# Altium schematic sheet XoomFloppy Pro.SchDoc("XoomFloppy Pro")
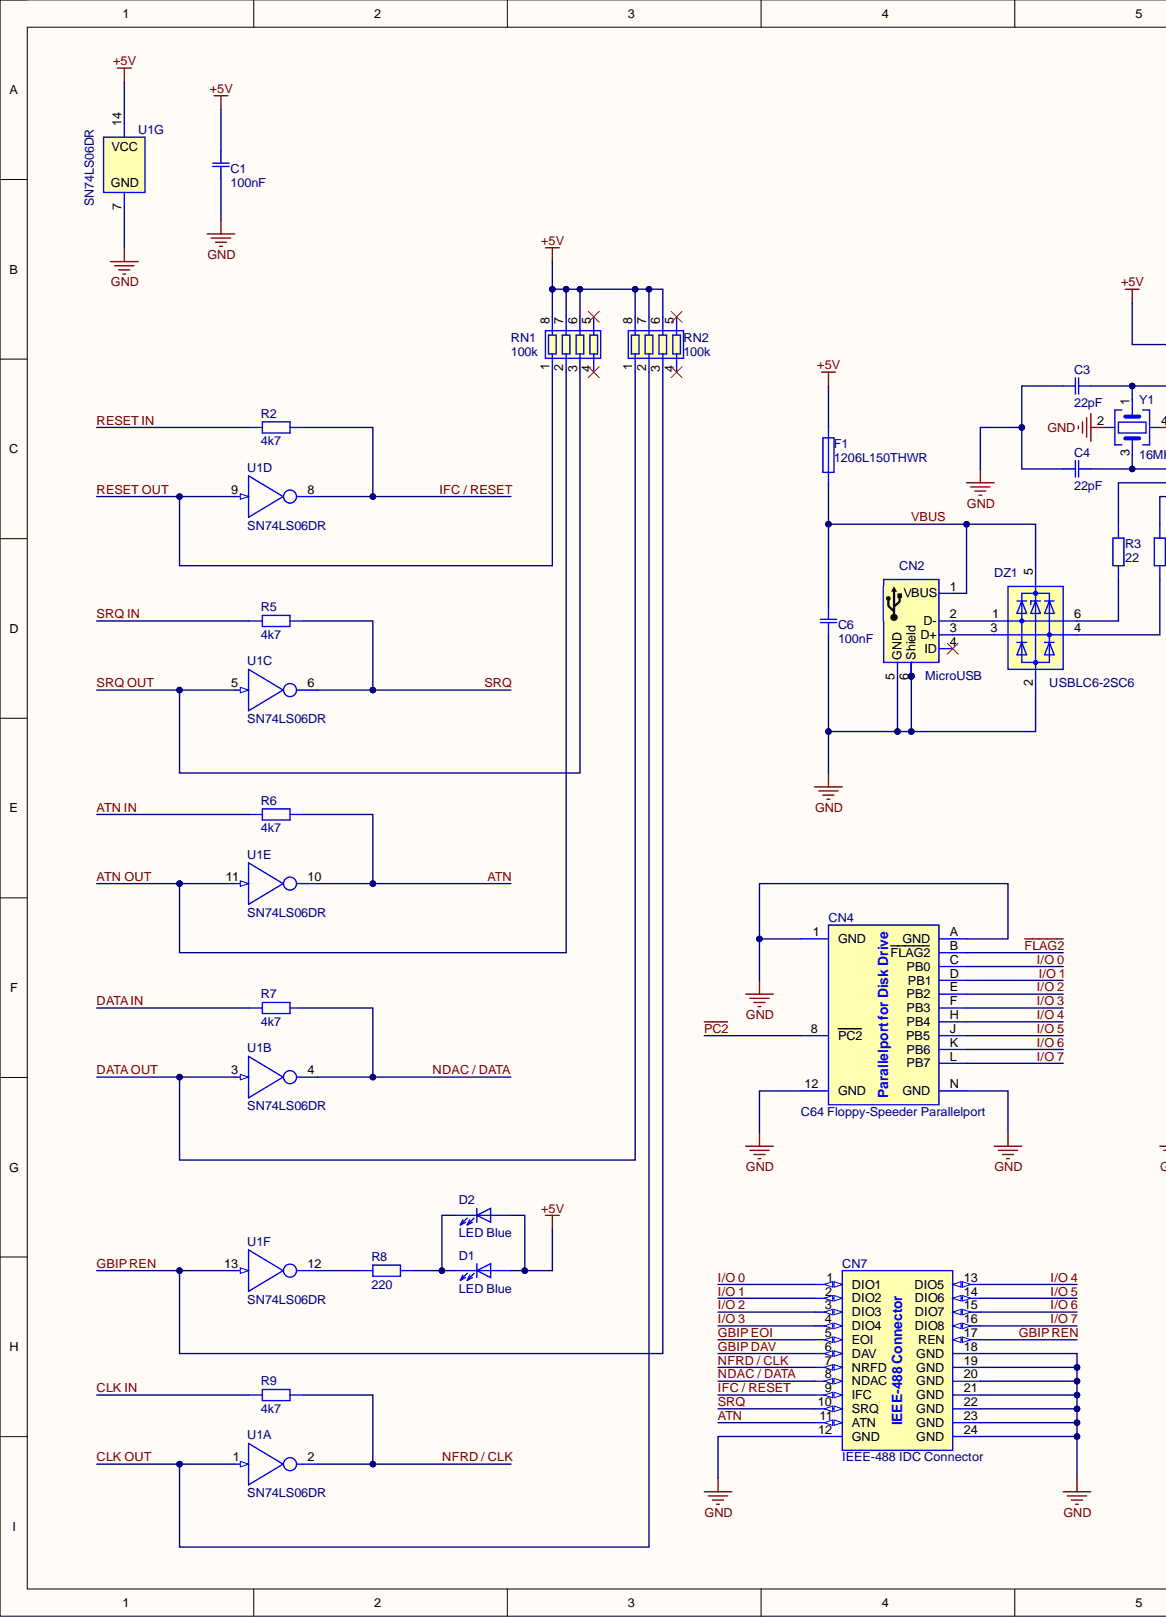
[diagram p0: XoomFloppy Pro.SchDoc, left]
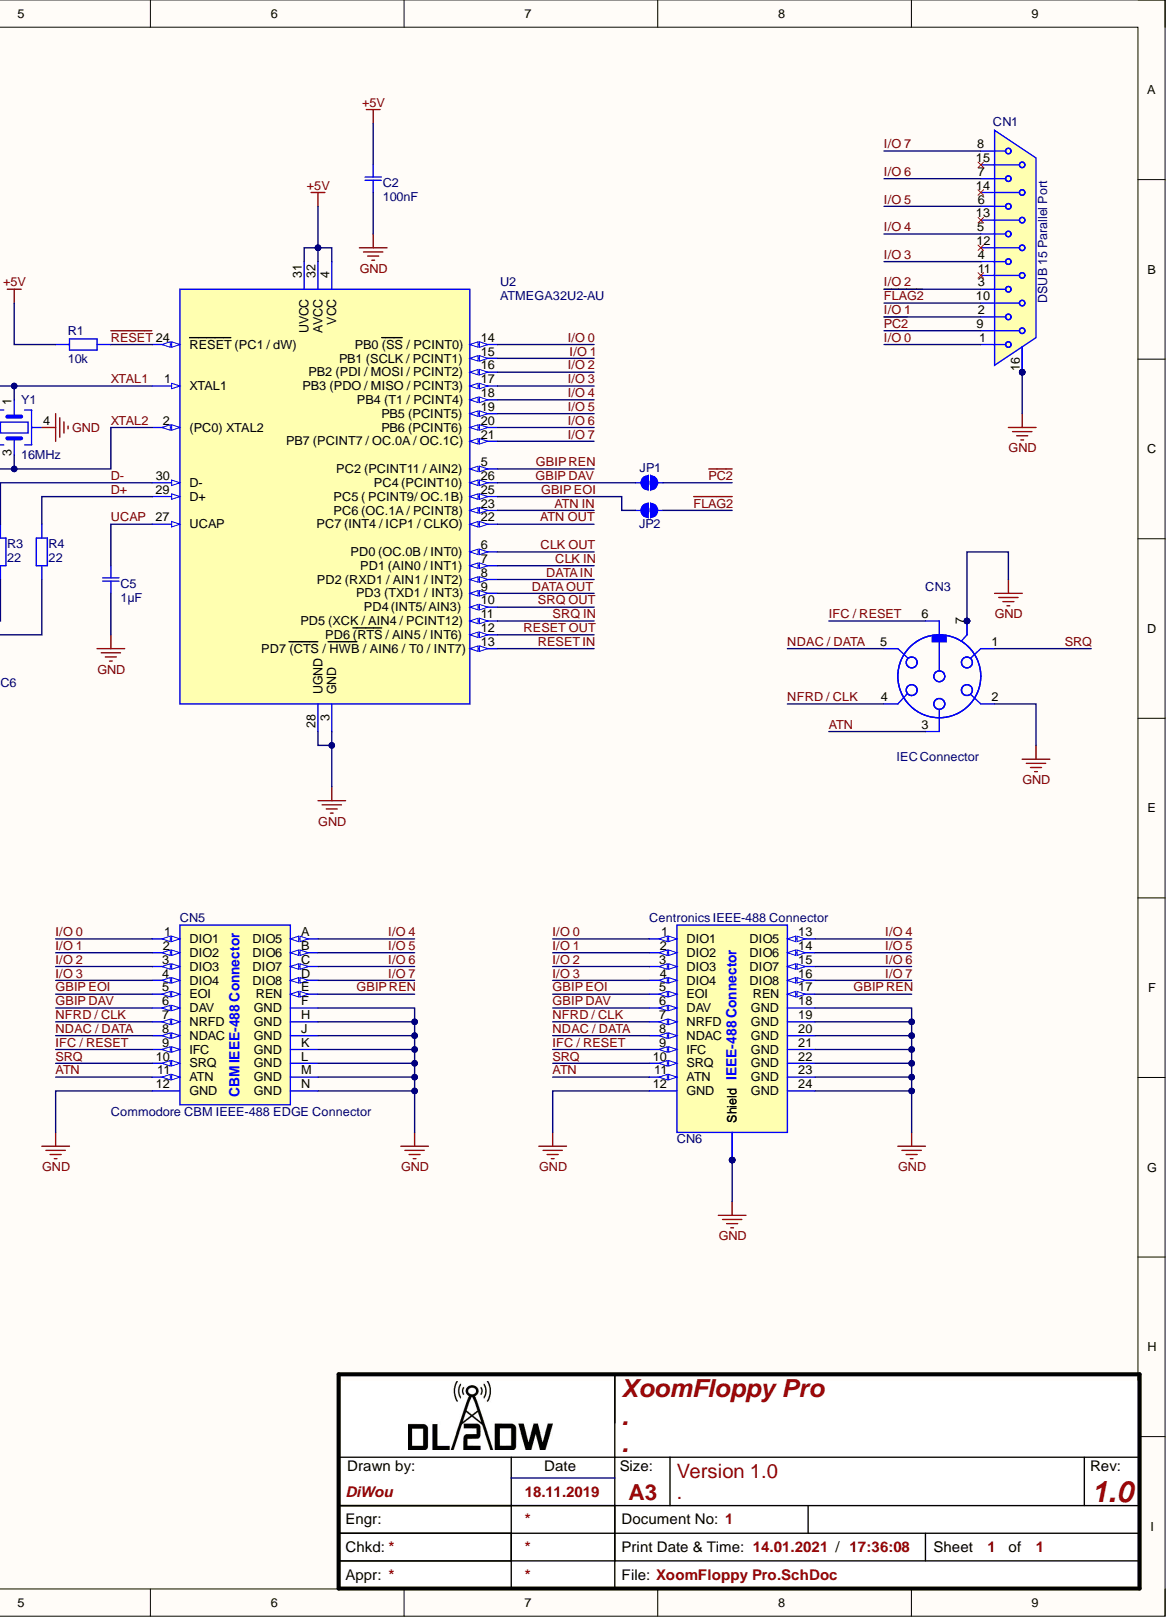
[diagram p1: XoomFloppy Pro.SchDoc, right]

## Parts
C1  100nF  [CAP 100nF SMD 0603 C0603C104J3RACTU]
C2  100nF  [CAP 100nF SMD 0603 C0603C104J3RACTU]
C3  22pF  [CAP 22pF SMD 0603 C0603C220J5RAC7867]
C4  22pF  [CAP 22pF SMD 0603 C0603C220J5RAC7867]
C5  1µF  [CAP 1µF SMD 0603 C0603C105K3RACTU]
C6  100nF  [CAP 100nF SMD 0603 C0603C104J3RACTU]
CN1  DSUB 15 Parallel Port  [D-SUB 15 Male Connector Right Angle 8.1mm]
CN2  MicroUSB  [MicroUSB Connector Right Angle Receptacle (Würth 629105150521)]
CN3  IEC Connector  [DIN 45322 Circular Connector (DIN-6)]
CN4  C64 Floppy-Speeder Parallelport  [C64 User Port]
CN5  Commodore CBM IEEE-488 EDGE Connector  [CBM IEEE-488 EDGE Connector]
CN6  Centronics IEEE-488 Connector  [GPIB Centronics Female 2x12pos. Connector]
CN7  IEEE-488 IDC Connector  [IEEE-488 IDC Header 2x12 P2.54mm Straight]
D1  LED Blue  Color: Blue  [LED Blue SMD 0603]
D2  LED Blue  Color: Blue  [LED 3mm Blue Straight]
DZ1  USBLC6-2SC6  [USBLC6-2SC6]
F1  1206L150THWR  [Littelfuse 1206L150THWR]
JP1  Solder Jumper Open  [Solder Jumper Open Variant 2]  (value not drawn)  (jumper)
JP2  Solder Jumper Open  [Solder Jumper Open Variant 2]  (value not drawn)  (jumper)
R1  10k  [10k Ohm R 0603 SMD]
R2  4k7  [4,7k Ohm R 0603 SMD]
R3  22  [22 Ohm R 0603 SMD]
R4  22  [22 Ohm R 0603 SMD]
R5  4k7  [4,7k Ohm R 0603 SMD]
R6  4k7  [4,7k Ohm R 0603 SMD]
R7  4k7  [4,7k Ohm R 0603 SMD]
R8  220  [220 Ohm R 0603 SMD]
R9  4k7  [4,7k Ohm R 0603 SMD]
RN1  100k  [RES ARRAY 4 RES 100K OHM 0804]
RN2  100k  [RES ARRAY 4 RES 100K OHM 0804]
U1  SN74LS06DR  [SN74LS06DR SOIC-14 P1.27mm W3.9mm]  (parts A,B,C,D,E,F,G)
U2  ATMEGA32U2-AU  [TQFP-32 P0.8mm 7x7mm ATMega32u2]
Y1  16MHz  [Crystal 16MHz SMD 2.0x1.6mm 4pos GND2-4]

## Nets
+5V: C1.2 C2.2 D1.2 D2.2 F1.2 R1.1 RN1.6 RN1.7 RN1.8 RN2.6 RN2.7 RN2.8 U1.14 U2.4(VCC) U2.31(UVCC) U2.32(AVCC)
GND: C1.1 C2.1 C3.1 C4.1 C5.1 C6.1 CN1.16 CN1.17 CN2.5(GND) CN2.6(Shield) CN2.7 CN2.8 CN2.9 CN3.2 CN3.7 CN3.8 CN4.1(GND) CN4.12(GND) CN4.A(GND) CN4.N(GND) CN5.12(GND) CN5.F(GND) CN5.H(GND) CN5.J(GND) CN5.K(GND) CN5.L(GND) CN5.M(GND) CN5.N(GND) CN6.12(GND) CN6.18(GND) CN6.19(GND) CN6.20(GND) CN6.21(GND) CN6.22(GND) CN6.23(GND) CN6.24(GND) CN6.Shield1(Shield) CN6.Shield2 CN7.12(GND) CN7.18(GND) CN7.19(GND) CN7.20(GND) CN7.21(GND) CN7.22(GND) CN7.23(GND) CN7.24(GND) DZ1.2 U1.7 U2.3(GND) U2.28(UGND) Y1.2 Y1.4
~{FLAG2}: CN1.10 CN4.B(FLAG2) JP2.2
~{PC2}: CN1.9 CN4.8(PC2) JP1.2
~{RESET}: R1.2 U2.24(RESET_(PC1_/_dW))
ATN: CN3.3 CN5.11(ATN) CN6.11(ATN) CN7.11(ATN) R6.2 U1.10
ATN IN: R6.1 U2.23(PC6_(OC.1A_/_PCINT8))
ATN OUT: RN1.2 U1.11 U2.22(PC7_(INT4_/_ICP1_/_CLKO))
CLK IN: R9.1 U2.7(PD1_(AIN0_/_INT1))
CLK OUT: RN2.2 U1.1 U2.6(PD0_(OC.0B_/_INT0))
D+: R4.2 U2.29(D+)
D-: R3.2 U2.30(D-)
DATA IN: R7.1 U2.8(PD2_(RXD1_/_AIN1_/_INT2))
DATA OUT: RN2.1 U1.3 U2.9(PD3_(TXD1_/_INT3))
GBIP DAV: CN5.6(DAV) CN6.6(DAV) CN7.6(DAV) JP1.1 U2.26(PC4_(PCINT10))
GBIP EOI: CN5.5(EOI) CN6.5(EOI) CN7.5(EOI) JP2.1 U2.25(PC5_(_PCINT9/_OC.1B))
GBIP REN: CN5.E(REN) CN6.17(REN) CN7.17(REN) RN2.3 U1.13 U2.5(PC2_(PCINT11_/_AIN2))
I/O 0: CN1.1 CN4.C(PB0) CN5.1(DIO1) CN6.1(DIO1) CN7.1(DIO1) U2.14(PB0_(SS_/_PCINT0))
I/O 1: CN1.2 CN4.D(PB1) CN5.2(DIO2) CN6.2(DIO2) CN7.2(DIO2) U2.15(PB1_(SCLK_/_PCINT1))
I/O 2: CN1.3 CN4.E(PB2) CN5.3(DIO3) CN6.3(DIO3) CN7.3(DIO3) U2.16(PB2_(PDI_/_MOSI_/_PCINT2))
I/O 3: CN1.4 CN4.F(PB3) CN5.4(DIO4) CN6.4(DIO4) CN7.4(DIO4) U2.17
I/O 4: CN1.5 CN4.H(PB4) CN5.A(DIO5) CN6.13(DIO5) CN7.13(DIO5) U2.18(PB4_(T1_/_PCINT4))
I/O 5: CN1.6 CN4.J(PB5) CN5.B(DIO6) CN6.14(DIO6) CN7.14(DIO6) U2.19(PB5_(PCINT5))
I/O 6: CN1.7 CN4.K(PB6) CN5.C(DIO7) CN6.15(DIO7) CN7.15(DIO7) U2.20(PB6_(PCINT6))
I/O 7: CN1.8 CN4.L(PB7) CN5.D(DIO8) CN6.16(DIO8) CN7.16(DIO8) U2.21(PB7_(PCINT7_/_OC.0A_/_OC.1C))
IFC / RESET: CN3.6 CN5.9(IFC) CN6.9(IFC) CN7.9(IFC) R2.2 U1.8
NDAC / DATA: CN3.5 CN5.8(NDAC) CN6.8(NDAC) CN7.8(NDAC) R7.2 U1.4
NFRD / CLK: CN3.4 CN5.7(NRFD) CN6.7(NRFD) CN7.7(NRFD) R9.2 U1.2
RESET IN: R2.1 U2.13(PD7_(CTS_/_HWB_/_AIN6_/_T0_/_INT7))
RESET OUT: RN1.1 U1.9 U2.12(PD6_(RTS_/_AIN5_/_INT6))
SRQ: CN3.1 CN5.10(SRQ) CN6.10(SRQ) CN7.10(SRQ) R5.2 U1.6
SRQ IN: R5.1 U2.11(PD5_(XCK_/_AIN4_/_PCINT12))
SRQ OUT: RN1.3 U1.5 U2.10(PD4_(INT5/_AIN3))
UCAP: C5.2 U2.27(UCAP)
VBUS: C6.2 CN2.1(VBUS) DZ1.5 F1.1
XTAL1: C3.2 U2.1(XTAL1) Y1.1
XTAL2: C4.2 U2.2((PC0)_XTAL2) Y1.3
NetCN2_2: CN2.2(D-) DZ1.1
NetCN2_3: CN2.3(D+) DZ1.3
NetD1_1: D1.1 D2.1 R8.2
NetDZ1_4: DZ1.4 R4.1
NetDZ1_6: DZ1.6 R3.1
NetR8_1: R8.1 U1.12

Not connected: CN1 11 12 13 14 15; CN2 4(ID); RN1 4 5; RN2 4 5

## Library data of the parts
C1 C2 C6 = CMP-Capacitors-Ceramic_Capacitors-00012-1: KEMET         C0603C104J3RACTU             SMD Multilayer Ceramic Capacitor, 0603 [1608 Metric], 0.1 F, 25 V,  5%, X7R, C Series; Voltage: 25V; Capacitance: 100nF; Case Code (Imperial): 0603; Case Code (Metric): 1608; Case/Package: 0603; Component Kind: Standard; Contact Plating: Tin,Matte; Depth: 0.8mm; Dielectric: X7R; Dielectric Material: Ceramic; Height: 0.8mm; Lead Pitch: 0.7mm; Length: 1.6mm; Library Name: PCB-Tech Cloud; Max Operating Temperature: 125°C; Min Operating Temperature: -55°C; Mount: Surface Mount,PCB; Number of Pins: 2; Package Quantity: 4000; Packaging: Tape and Reel; Radiation Hardening: No; REACH SVHC: No SVHC; Termination: SMD/SMT; Thickness: 0.034inch; Tolerance: 5%; Voltage Rating: 25V; Voltage Rating (DC): 25V; Width: 0.031inch
C3 C4 = CMP-Capacitors-Ceramic_Capacitors-00013-1: 22.0PF 50.0V; Capacitance: 2.2E-11F; Dielectric: X7R; Tolerance: 5%; Voltage Rating (DC): 50V; Case/Package: 0603; Component Kind: Standard; Dielectric Material: Ceramic; Library Name: PCB-Tech Cloud
C5 = CMP-Capacitors-Ceramic_Capacitors-00014-1: KEMET 1F Multilayer Ceramic Capacitor (MLCC) 25 V 10% X7R dielectric C SMD max op. temp. +125C; Capacitance: 1uF; Dissipation Factor: 5%; Voltage: 25V; Case Code (Imperial): 0603; Case Code (Metric): 1608; Case/Package: 0603; Component Kind: Standard; Depth: 0.8mm; Dielectric: X7R; Dielectric Material: Ceramic; Height: 0.8mm; Length: 1.6mm; Library Name: PCB-Tech Cloud; Max Operating Temperature: 125°C; Min Operating Temperature: -55°C; Mount: Surface Mount; Package Quantity: 1; Packaging: Tape and Reel; REACH SVHC: No SVHC; Termination: SMD/SMT; Thickness: 0.034inch; Tolerance: 10%; Voltage Rating: 25V; Voltage Rating (DC): 25V; Width: 0.031inch
CN1 = CMP-D-SUB_Connectors-00000-6: HARTING         09 64 223 7802             Filtered D Sub Connector, Ferrite, DA, Plug, 15 Contacts, 0 F, Steel Body; Capacitance: 0pF; Connector Type: D-Sub,Plug,Signal; Current Rating: 7.5A; Gender: Male; Number of Contacts: 15; Pitch: 2.77mm; Voltage Rating: 250V; Body Material: Steel; Component Kind: Standard; Contact Plating: Gold; Depth: 12.5mm; Housing Material: PBT; Length: 39.2mm; Library Name: PCB-Tech Cloud; Material: Polybutylene; Max Operating Temperature: 105°C; Min Operating Temperature: -55°C; Mount: Through Hole,Panel; Number of Positions: 15; Number of Rows: 2; Orientation: Right Angle; Package Quantity: 70; Packaging: Bulk; REACH SVHC: No SVHC; Termination: Solder; Width: 18.5mm
CN2 = CMP-Connectors-USB_Connectors-00001-1: Socket micro-USB B 5P, 629105150521, Würth Elektronik; Connector Type: USB; Gender: Receptacle; Number of Contacts: 5; USB Standard: USB 2.0; Component Kind: Standard; Contact Material: Copper Alloy; Contact Plating: Gold; Features: Shielded; Lead Free: Lead Free; Library Name: PCB-Tech Cloud; Mount: Surface Mount,Horizontal; Orientation: Right Angle; Packaging: Tape and Reel; REACH SVHC: Unknown; Termination: SMD/SMT
CN3 = CMP-Connectors-DIN_Connectors00000-3: DIN chassis socket, right angle version, with ground contact, suitable for locking, for printed circuit boards; Component Kind: Standard; Library Name: PCB-Tech Cloud
CN4 = CMP-Commodore-00005-4: EDGE Connector like C64 Userport for "SpeedDOS"; Component Kind: Standard; Library Name: PCB-Tech Cloud
CN5 = CMP-Commodore-00003-4: PCB connector for connecting IEEE-488 devices; Component Kind: Standard; Library Name: PCB-Tech Cloud
CN6 = CMP-Connectors-00013-5: L-COM - CIB24SRA - CONNECTOR GPIB R/A FEMALE; Component Kind: Standard; Library Name: PCB-Tech Cloud
CN7 = CMP-Commodore-00004-4: IEEE-488 IDC Connector Right Angle or Straight; Component Kind: Standard; Library Name: PCB-Tech Cloud
D1 = CMP-LEDs-00001-1: LED BLUE DIFFUSED 0603 SMD; Dominant Wavelength: 470nm; Forward Voltage: 3.2V; Lens Size: 0.8mm; Lens Style: Flat; Luminous Intensity: 80mcd; Test Current: 20mA; Viewing Angle: 140°; Case/Package: 0603; Component Kind: Standard; Height: 0.5mm; Length: 1.6mm; Lens Transparency: Diffused; Library Name: PCB-Tech Cloud; Mount: Surface Mount; Packaging: Tape and Reel; Width: 0.8mm
D2 = CMP-LEDs-0000-4: WURTH ELEKTRONIK   151033BS03000   LED, 3MM, BLUE, 3800MCD, 470NM; Forward Current: 30mA; Forward Voltage: 2.8V; Lens Style: Clear,Circular,Round; Test Current: 20mA; Viewing Angle: 30°; Case/Package: Radial; Component Kind: Standard; Dominant Wavelength: 470nm; Height: 5.55mm; Lens Size: 3mm; Library Name: PCB-Tech Cloud; Luminous Intensity: 3800mcd; Max Operating Temperature: 85°C; Min Operating Temperature: -40°C; Mount: Through Hole; Package Quantity: 1; Packaging: Bulk; REACH SVHC: Unknown; Wavelength: 470nm
DZ1 = CMP-Diodes-00005-3: TVS DIODE 5.25V 17V SOT23-6; Breakdown Voltage: 6V; Capacitance: 3.5pF; Data Rate: 480Mbps; Direction: Unidirectional,Bidirectional; Element Configuration: Dual; ESD Protection: Yes; Leakage Current: 150nA; Number of Bidirectional Channels: 2; Number of Elements: 1; Operating Supply Voltage: 1.1V; Peak Pulse Current: 5A; Test Current: 1mA; Working Voltage: 5V; Case/Package: SOT-23; Clamping Voltage: 17V; Component Kind: Standard; Contact Plating: Tin,Matte; Depth: 1.75mm; Height: 1.3mm; Lead Free: Lead Free; Length: 3.05mm; Library Name: PCB-Tech Cloud; Max Breakdown Voltage: 6V; Max Operating Temperature: 125°C; Max Reverse Leakage Current: 150nA; Min Breakdown Voltage: 6V; Min Operating Temperature: -40°C; Mount: Surface Mount; Number of Channels: 2; Number of Pins: 6; Package Quantity: 3000; Packaging: Tape and Reel; Polarity: Unidirectional,Bidirectional; Power Line Protection: Yes; Radiation Hardening: No; REACH SVHC: No SVHC; Reverse Breakdown Voltage: 6V; Reverse Standoff Voltage: 5.25V; Termination: SMD/SMT; Width: 1.75mm
F1 = CMP-Fuses-0001-1: PTC RESET FUSE 8V 1.5A 1206; Approvals: cULus,TUV; Current Rating: 100A; Power Dissipation: 800mW; Resistance: 120mR; Time to Trip: 0.3s; Trip Current: 3A; Working Voltage: 6V; Case Code (Imperial): 1206; Case Code (Metric): 3216; Case/Package: 1206; Component Kind: Standard; Height: 0.75mm; Hold Current: 1.5A; Lead Free: Lead Free; Length: 3.4mm; Library Name: PCB-Tech Cloud; Max Current Rating: 100A; Max Operating Temperature: 85°C; Max Voltage Rating (DC): 8V; Min Operating Temperature: -40°C; Operating Supply Voltage: 8V; Package Quantity: 3000; Packaging: Tape and Reel; REACH SVHC: Unknown; Terminal Material: Copper; Termination: SMD/SMT; Voltage Rating: 8V; Voltage Rating (DC): 8V; Width: 1.8mm
JP1 JP2 = CMP-Mechanicals-00016-12: Description: Solder Jumper Open; Library Name: PCB-Tech Cloud
R1 = CMP-Resistors-Yageo_SMD_0805-0170-1: RES SMD 10K OHM 1% 1/10W 0603; Power Rating: 100mW; Resistance: 10kR; Temperature Coefficient: 100ppm/°C; Voltage Rating: 75V; Voltage Rating (DC): 50V; Case Code (Imperial): 0603; Case Code (Metric): 1608; Case/Package: 0603; Component Kind: Standard; Composition: Thick Film; Depth: 0.8mm; Height: 0.45mm; Lead Free: Lead Free; Lead Pitch: 0.3mm; Length: 1.6mm; Library Name: PCB-Tech Cloud; Max Operating Temperature: 155°C; Military Standard: Not; Min Operating Temperature: -55°C; Number of Pins: 2; Packaging: Tape and Reel; REACH SVHC: No SVHC; Termination: SMD/SMT; Tolerance: 1%; Weight: 7.1E-05oz; Width: 0.8mm
R2 R5-R7 R9 = CMP-Resistors-Yageo_SMD_0805-0169-1: RES SMD 4.7K OHM 1% 1/10W 0603; Power Rating: 100mW; Resistance: 4.7kR; Temperature Coefficient: 100ppm/°C; Voltage Rating: 50V; Case Code (Imperial): 0603; Case Code (Metric): 1608; Case/Package: 0603; Component Kind: Standard; Composition: Thick Film; Depth: 0.8mm; Height: 0.45mm; Lead Free: Lead Free; Lead Pitch: 0.3mm; Length: 1.6mm; Library Name: PCB-Tech Cloud; Max Operating Temperature: 155°C; Military Standard: Not; Min Operating Temperature: -55°C; Number of Pins: 2; Packaging: Tape and Reel; REACH SVHC: No SVHC; Termination: SMD/SMT; Tolerance: 1%; Voltage Rating (DC): 50V; Width: 0.8mm
R3 R4 = CMP-Resistors-Yageo_SMD_0805-0172-1: RES SMD 22 OHM 1% 1/10W 0603; Max Power Dissipation: 100mW; Resistance: 22R; Temperature Coefficient: 100ppm/°C; Voltage Rating: 50V; Case Code (Imperial): 0603; Case Code (Metric): 1608; Case/Package: 0603; Component Kind: Standard; Composition: Thick Film; Depth: 0.8mm; Features: Moisture Resistant; Height: 0.022inch; Lead Free: Lead Free; Length: 1.6mm; Library Name: PCB-Tech Cloud; Max Operating Temperature: 155°C; Military Standard: Not; Min Operating Temperature: -55°C; Number of Pins: 2; Number of Terminations: 2; Package Quantity: 1; Packaging: Tape and Reel; Power Rating: 100mW; REACH SVHC: No SVHC; Termination: SMD/SMT; Tolerance: 1%; Voltage Rating (DC): 50V; Width: 0.031inch
R8 = CMP-Resistors-Yageo_SMD_0805-0171-1: RES SMD 220 OHM 1% 1/10W 0603; Max Power Dissipation: 100mW; Resistance: 220R; Temperature Coefficient: 100ppm/°C; Voltage Rating: 50V; Case Code (Imperial): 0603; Case Code (Metric): 1608; Case/Package: 0603; Component Kind: Standard; Composition: Thick Film; Depth: 0.8mm; Features: Moisture Resistant; Height: 0.022inch; Lead Free: Lead Free; Length: 1.6mm; Library Name: PCB-Tech Cloud; Max Operating Temperature: 155°C; Military Standard: Not; Min Operating Temperature: -55°C; Number of Pins: 2; Number of Terminations: 2; Package Quantity: 1; Packaging: Tape and Reel; Power Rating: 100mW; REACH SVHC: No SVHC; Termination: SMD/SMT; Tolerance: 1%; Voltage Rating (DC): 50V; Width: 0.031inch
RN1 RN2 = CMP-Resistors-00011-2: RES ARRAY 4 RES 100K OHM 0804; Circuit Type: Isolated; Number of Circuits: 4; Power Per Element: 62.5mW; Resistance: 100kR; Temperature Coefficient: 200ppm/°C; Tolerance: 1%; Case/Package: 0804; Component Kind: Standard; Height: 0.02inch; Length: 0.079inch; Library Name: PCB-Tech Cloud; Max Operating Temperature: 125°C; Min Operating Temperature: -55°C; Mount: Surface Mount; Number of Pins: 8; Number of Resistors: 4; Packaging: Tape and Reel; Width: 0.039inch
U1 = CMP-ICs-74xx-series-00051-2: IC INVERTER OPEN COL 6CH 14SOIC; High Level Output Current: -250uA; Logic Function: Buffer,Inverter; Low Level Output Current: 40mA; Max Supply Voltage: 5.25V; Min Supply Voltage: 4.75V; Number of Bits: 6; Operating Supply Voltage: 5V; Polarity: Inverting; Propagation Delay: 20ns; Case/Package: SOIC; Component Kind: Standard; Contact Plating: Gold; Lead Free: Lead Free; Library Name: PCB-Tech Cloud; Max Operating Temperature: 70°C; Min Operating Temperature: 0°C; Mount: Surface Mount; Number of Channels: 6; Number of Circuits: 6; Number of Elements: 6; Number of Gates: 6; Number of Input Lines: 6; Number of Inputs: 6; Number of Output Lines: 6; Number of Outputs: 6; Number of Pins: 14; Package Quantity: 1; Packaging: Tape and Reel; Radiation Hardening: No; REACH SVHC: No SVHC; Turn-On Delay Time: 20ns
U2 = CMP-ICs-00001-3: ATMEL         ATMEGA32U2-AU            MCU, 8BIT, MEGAAVR, 16MHZ, TQFP-32; Core Architecture: AVR; Data Bus Width: 8b; Density: 32KB; Interface: SPI,USB,UART,USART; Max Supply Voltage: 5.5V; Memory Type: FLASH; Min Supply Voltage: 2.7V; Number of I/Os: 22; Number of PWM Channels: 5; Number of SPI Channels: 1; Number of Timers/Counters: 2; Oscillator Type: Internal; Peripherals: Brown-out Detect/Reset,POR,PWM,WDT; RAM Size: 1KB; Watchdog Timer: Yes; Case/Package: A; Component Kind: Standard; Contact Plating: Tin,Matte; Frequency: 16MHz; Height: 1.05mm; Lead Free: Lead Free; Length: 7mm; Library Name: PCB-Tech Cloud; Max Frequency: 16MHz; Max Operating Temperature: 85°C; Memory Size: 32KB; Min Operating Temperature: -40°C; Mount: Surface Mount; Number of Pins: 32; Number of Programmable I/O: 22; Package Quantity: 1250; Packaging: Tray; Radiation Hardening: No; REACH SVHC: No SVHC; Termination: SMD/SMT; Width: 7mm
Y1 = CMP-Crystals_Oscilators-00001-1: 16MHz ±10ppm 9pF 200Ω SMD,2.0x1.6mm SMD Crystal Resonators RoHS; Component Kind: Standard; Library Name: PCB-Tech Cloud
